# Altium schematic sheet Microcontroller.SchDoc(Microcontroller)
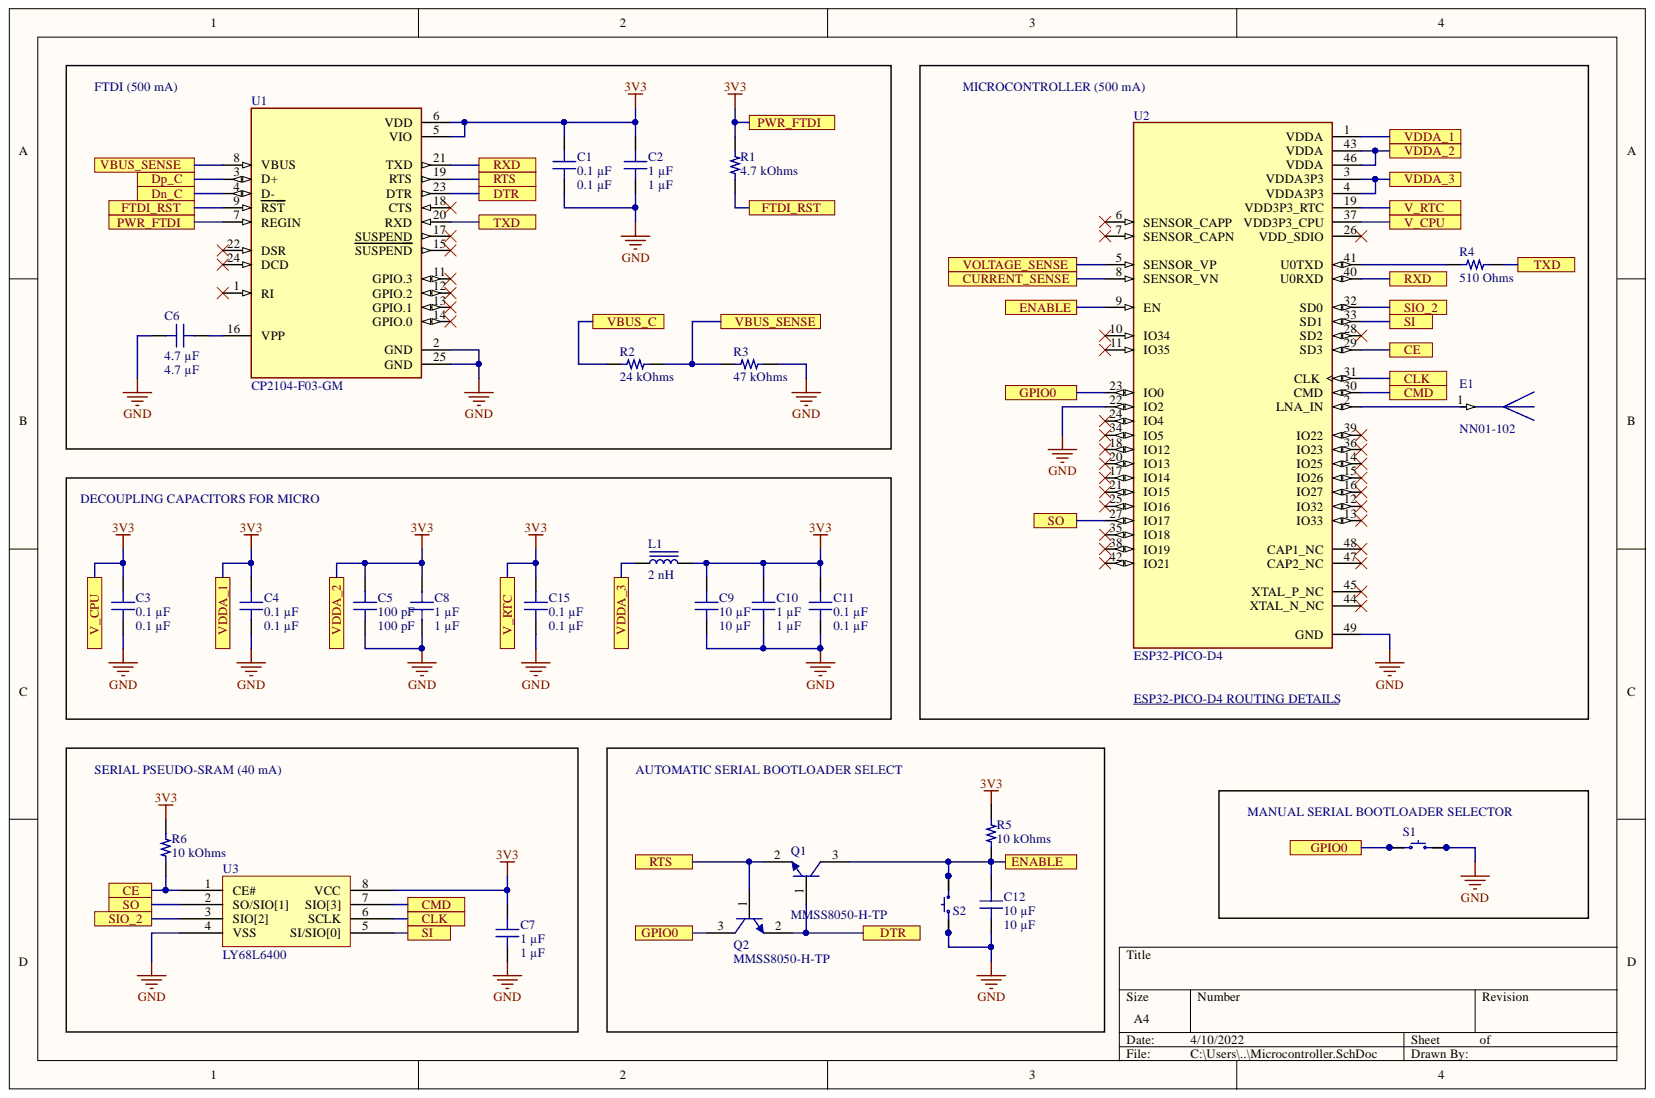
[diagram p0: Microcontroller.SchDoc]

## Parts
C1  0.1 µF  [CAP 0603_1608]
C2  1 µF  [CAP 0603_1608]
C3  0.1 µF  [CAP 0603_1608]
C4  0.1 µF  [CAP 0603_1608]
C5  100 pF  [CAP 0603_1608]
C6  4.7 µF  [CAP 0603_1608]
C7  1 µF  [CAP 0603_1608]
C8  1 µF  [CAP 0603_1608]
C9  10 µF  [CAP 0805_2012]
C10  1 µF  [CAP 0603_1608]
C11  0.1 µF  [CAP 0603_1608]
C12  10 µF  [CAP 0805_2012]
C15  0.1 µF  [CAP 0603_1608]
E1  NN01-102  [XCVR_NN01-102]
L1  2 nH  [IND 0402_1005]
Q1  MMSS8050-H-TP  [MCCSEMI SOT-23-3]
Q2  MMSS8050-H-TP  [MCCSEMI SOT-23-3]
R1  4.7 kOhms  [RES 0603_1608]
R2  24 kOhms  [RES 0603_1608]
R3  47 kOhms  [RES 0603_1608]
R4  510 Ohms  [RES 0603_1608]
R5  10 kOhms  [RES 0603_1608]
R6  10 kOhms  [RES 0603_1608]
S1  PTS645SM43SMTR92 LFS  [C&K PTS645]  (value not drawn)
S2  PTS645SM43SMTR92 LFS  [C&K PTS645]  (value not drawn)
U1  CP2104-F03-GM  [QFN50P400X400X80-25N]
U2  ESP32-PICO-D4  [PQFN50P700X700X104-49N]
U3  LY68L6400  [SOP-8_3.9x4.9x1.27P]

## Ports
CE, CLK, CMD, CURRENT_SENSE, Dn_C, Dp_C, DTR, ENABLE, FTDI_RST, GPIO0, PWR_FTDI, RTS, RXD, SI, SIO_2, SO, TXD, V_CPU, V_RTC, VBUS_C, VBUS_SENSE, VDDA_1, VDDA_2, VDDA_3, VOLTAGE_SENSE

## Nets
GND: C1.1 C2.2 C3.1 C4.2 C5.1 C6.2 C7.2 C8.1 C9.1 C10.1 C11.1 C12.1 C15.1 R3.1 S1.3 S1.4 S2.1 S2.2 U1.2(GND) U1.25(GND) U2.22(IO2) U2.49(GND) U3.4(VSS)
3V3: C1.2 C2.1 C3.2 C4.1 C5.2 C7.1 C8.2 C9.2 C10.2 C11.2 C15.2 L1.2 R1.2 R5.1 R6.1 U1.5(VIO) U1.6(VDD) U1.7(REGIN) U2.1(VDDA) U2.19(VDD3P3_RTC) U2.37(VDD3P3_CPU) U2.43(VDDA) U2.46(VDDA) U3.8(VCC) ; port PWR_FTDI, port VDDA_1, port VDDA_2, port V_CPU, port V_RTC
CE: R6.2 U2.29(SD3) U3.1(CE#) ; port CE
CLK: U2.31(CLK) U3.6(SCLK) ; port CLK
CMD: U2.30(CMD) U3.7(SIO[3]) ; port CMD
CURRENT_SENSE: U2.8(SENSOR_VN) ; port CURRENT_SENSE
Dn_C: U1.4(D-) ; port Dn_C
Dp_C: U1.3(D+) ; port Dp_C
DTR: Q1.1 Q2.2 U1.23(DTR) ; port DTR
ENABLE: C12.2 Q1.3 R5.2 S2.3 S2.4 U2.9(EN) ; port ENABLE
FTDI_RST: R1.1 U1.9(RST) ; port FTDI_RST
GPIO0: Q2.3 S1.1 S1.2 U2.23(IO0) ; port GPIO0
RTS: Q1.2 Q2.1 U1.19(RTS) ; port RTS
RXD: U1.21(TXD) U2.40(U0RXD) ; port RXD
SI: U2.33(SD1) U3.5(SI/SIO[0]) ; port SI
SIO_2: U2.32(SD0) U3.3(SIO[2]) ; port SIO_2
SO: U2.27(IO17) U3.2(SO/SIO[1]) ; port SO
TXD: R4.2 U1.20(RXD) ; port TXD
VBUS_C: R2.2 ; port VBUS_C
VBUS_SENSE: R2.1 R3.2 U1.8(VBUS) ; port VBUS_SENSE
VDDA_3: L1.1 U2.3(VDDA3P3) U2.4(VDDA3P3) ; port VDDA_3
VOLTAGE_SENSE: U2.5(SENSOR_VP) ; port VOLTAGE_SENSE
NetC6_1: C6.1 U1.16(VPP)
NetE1_1: E1.1 U2.2(LNA_IN)
NetR4_1: R4.1 U2.41(U0TXD)

Not connected: U1 1(RI) 11(GPIO.3) 12(GPIO.2) 13(GPIO.1) 14(GPIO.0) 15(SUSPEND) 17(SUSPEND) 18(CTS) 22(DSR) 24(DCD); U2 6(SENSOR_CAPP) 7(SENSOR_CAPN) 10(IO34) 11(IO35) 12(IO32) 13(IO33) 14(IO25) 15(IO26) 16(IO27) 17(IO14) 18(IO12) 20(IO13) 21(IO15) 24(IO4) 25(IO16) 26(VDD_SDIO) 28(SD2) 34(IO5) 35(IO18) 36(IO23) 38(IO19) 39(IO22) 42(IO21) 44(XTAL_N_NC) 45(XTAL_P_NC) 47(CAP2_NC) 48(CAP1_NC)

## Library data of the parts
C1 C3 C4 C11 C15 = C0603C104K5RAC7411: CAP CER 0.1UF 50V X7R 0603; manufacturer: KEMET; Tolerance: ±10%; Database Table Name: Capacitors - Ceramic - 0603; Voltage - Rated: 50V; Value: 0.1 µF; Thickness (Max): 0.035" (0.90mm); Temperature Coefficient: X7R; Supplier Part Number 1: 399-7374-2-ND; Supplier 1: DigiKey; Size / Dimension: 0.063" L x 0.031" W (1.60mm x 0.80mm); series: SMD Comm X7R; price: 0.01; Part Status: Active; Part Number: C0603C104K5RAC7411; partid: 2233; packaging: TapeAndReel; Package / Case: 0603 (1608 Metric); Operating Temperature: -55°C ~ 125°C; Mounting Type: Surface Mount, MLCC; Minimum Order: 15000; Lifecycle Status: Active; Library Name: Celestial Altium Library - altium_library.DbLib; lastupdated: 21/08/2022 8:00:52 PM; Device Package: 0603 (1608 Metric); componentlink2url: https://www.digikey.com/en/products/detail/kemet/C0603C104K5RAC7411/3317013; componentlink2description: DigiKey Link; componentlink1url: https://api.kemet.com/component-edge/download/datasheet/C0603C104K5RAC7411.pdf; componentlink1description: Datasheet; Component Kind: Standard; Capacitance: 0.1 µF; Applications: Bypass, Decoupling
C2 C7 C8 C10 = CC0603KRX7R6BB105: CAP CER 1UF 10V X7R 0603; manufacturer: Yageo; Tolerance: ±10%; Database Table Name: Capacitors - Ceramic - 0603; Voltage - Rated: 10V; Value: 1 µF; Thickness (Max): 0.035" (0.90mm); Temperature Coefficient: X7R; Supplier Part Number 1: 311-1796-1-ND; Supplier 1: DigiKey; Size / Dimension: 0.063" L x 0.031" W (1.60mm x 0.80mm); series: CC; price: 0.02; Part Status: Active; Part Number: CC0603KRX7R6BB105; partid: 2290; packaging: TapeAndReel; Package / Case: 0603 (1608 Metric); Operating Temperature: -55°C ~ 125°C; Mounting Type: Surface Mount, MLCC; Minimum Order: 1; Lifecycle Status: Active; Library Name: Celestial Altium Library - altium_library.DbLib; lastupdated: 21/08/2022 8:00:52 PM; Device Package: 0603 (1608 Metric); componentlink2url: https://www.digikey.com/en/products/detail/yageo/CC0603KRX7R6BB105/5195204; componentlink2description: DigiKey Link; componentlink1url: https://www.yageo.com/upload/media/product/productsearch/datasheet/mlcc/UPY-GPHC_X7R_6.3V-to-50V_18.pdf; componentlink1description: Datasheet; Component Kind: Standard; Capacitance: 1 µF; Applications: General Purpose
C5 = C0603C101K5GACAUTO: CAP CER 100PF 50V C0G/NP0 0603; manufacturer: KEMET; Tolerance: ±10%; Temperature Coefficient: C0G, NP0; Database Table Name: Capacitors - Ceramic - 0603; Voltage - Rated: 50V; Value: 100 pF; Thickness (Max): 0.034" (0.87mm); Supplier Part Number 1: 399-6843-1-ND; Supplier 1: DigiKey; Size / Dimension: 0.063" L x 0.031" W (1.60mm x 0.80mm); series: SMD Auto C0G; Ratings: AEC-Q200; price: 0.01; Part Status: Active; Part Number: C0603C101K5GACAUTO; partid: 2201; packaging: TapeAndReel; Package / Case: 0603 (1608 Metric); Operating Temperature: -55°C ~ 125°C; Mounting Type: Surface Mount, MLCC; Minimum Order: 1; Lifecycle Status: Active; Library Name: Celestial Altium Library - altium_library.DbLib; lastupdated: 25/08/2022 6:49:41 AM; Features: Low ESL; Device Package: 0603 (1608 Metric); componentlink2url: https://www.digikey.com/en/products/detail/kemet/C0603C101K5GACAUTO/3314842; componentlink2description: DigiKey Link; componentlink1url: https://api.kemet.com/component-edge/download/datasheet/C0603C101K5GACAUTO.pdf; componentlink1description: Datasheet; Component Kind: Standard; Capacitance: 100 pF; Applications: Automotive
C6 = GRM188Z71C475KE21J: CAP CER 4.7UF 16V X7R 0603; manufacturer: Murata Electronics; Tolerance: ±10%; Database Table Name: Capacitors - Ceramic - 0603; Voltage - Rated: 16V; Value: 4.7 µF; Thickness (Max): 0.039" (1.00mm); Temperature Coefficient: X7R; Supplier Part Number 1: 490-GRM188Z71C475KE21JCT-ND; Supplier 1: DigiKey; Size / Dimension: 0.063" L x 0.031" W (1.60mm x 0.80mm); series: GRM; price: 0.04; Part Status: Active; Part Number: GRM188Z71C475KE21J; partid: 41988; packaging: TapeAndReel; Package / Case: 0603 (1608 Metric); Operating Temperature: -55°C ~ 125°C; Mounting Type: Surface Mount, MLCC; Minimum Order: 1; Lifecycle Status: Active; Library Name: Celestial Altium Library - altium_library.DbLib; lastupdated: 21/08/2022 7:59:26 PM; Device Package: 0603 (1608 Metric); componentlink2url: https://www.digikey.com/en/products/detail/murata-electronics/GRM188Z71C475KE21J/16033436; componentlink2description: DigiKey Link; componentlink1url: https://search.murata.co.jp/Ceramy/image/img/A01X/G101/ENG/GRM188Z71C475KE21-01A.pdf; componentlink1description: Datasheet; Component Kind: Standard; Capacitance: 4.7 µF; Applications: General Purpose
C9 C12 = CGA4J1X7R0J106K125AC: CAP CER 10UF 6.3V X7R 0805; manufacturer: TDK Corporation; Tolerance: ±10%; Database Table Name: Capacitors - Ceramic - 0805; Voltage - Rated: 6.3V; Value: 10 µF; Thickness (Max): 0.057" (1.45mm); Temperature Coefficient: X7R; Supplier Part Number 1: 445-6971-1-ND; Supplier 1: DigiKey; Size / Dimension: 0.079" L x 0.049" W (2.00mm x 1.25mm); series: CGA; Ratings: AEC-Q200; price: 0.07; Part Status: Active; Part Number: CGA4J1X7R0J106K125AC; partid: 3016; packaging: TapeAndReel; Package / Case: 0805 (2012 Metric); Operating Temperature: -55°C ~ 125°C; Mounting Type: Surface Mount, MLCC; Minimum Order: 1; Lifecycle Status: Active; Library Name: Celestial Altium Library - altium_library.DbLib; lastupdated: 21/08/2022 8:04:19 PM; Device Package: 0805 (2012 Metric); componentlink2url: https://www.digikey.com/en/products/detail/tdk-corporation/CGA4J1X7R0J106K125AC/2672864; componentlink2description: DigiKey Link; componentlink1url: https://product.tdk.com/info/en/catalog/datasheets/mlcc_automotive_general_en.pdf?ref_disty=digikey; componentlink1description: Datasheet; Component Kind: Standard; Capacitance: 10 µF; Applications: Automotive
E1 = NN01-102: 2.45GHz Bluetooth, WLAN, Zigbee Chip RF Antenna 2.4GHz ~ 2.5GHz 1.7dBi Solder Surface Mount; MF: {'manufacturer': u'Ignion'}; Price: None; Package: None; MP: NN01-102; Library Name: NN01-102.SchLib; Component Kind: Standard; Availability: Unavailable
L1 = MHQ1005P2N0BT000: FIXED IND 2NH 1A 50 MOHM SMD; Manufacturer: TDK Corporation; Type: Multilayer; Tolerance: ±0.1nH; Shielding: Unshielded; Q @ Freq: 23 @ 250MHz; Material - Core: Ceramic, Non-Magnetic; Inductance Frequency - Test: 100 MHz; Frequency - Self Resonant: 8GHz; DC Resistance (DCR): 50mOhm Max; Database Table Name: Inductors - Power; Value: 2 nH; Supplier Part Number 1: 445-MHQ1005P2N0BT000CT-ND; Supplier Device Package: 0402 (1005 Metric); Supplier 1: DigiKey; Size / Dimension: 0.039" L x 0.024" W (1.00mm x 0.60mm); Series: MHQ-P; Price: 0.06; Part Status: Active; Part Number: MHQ1005P2N0BT000; PartId: 1330; Packaging: TapeAndReel; Package / Case: 0402 (1005 Metric); Operating Temperature: -55°C ~ 125°C; Mounting Type: Surface Mount; Minimum Order: 1; Lifecycle Status: Active; Library Name: Celestial Altium Library - altium_library.DbLib; LastUpdated: 1/09/2022 1:22:23 PM; Inductance: 2 nH; Height - Seated (Max): 0.024" (0.60mm); Device Package: 0402 (1005 Metric); Current Rating (Amps): 1 A; ComponentLink2URL: https://www.digikey.com/en/products/detail/tdk-corporation/MHQ1005P2N0BT000/4742522; ComponentLink2Description: DigiKey Link; ComponentLink1URL: https://product.tdk.com/info/en/catalog/datasheets/inductor_commercial_high-frequency_mhq1005p_en.pdf?ref_disty=digikey; ComponentLink1Description: Datasheet; Component Kind: Standard
Q1 Q2 = MMSS8050-H-TP: TRANS NPN 25V 1.5A SOT23; Manufacturer: Micro Commercial Co; Vce Saturation (Max) @ Ib, Ic: 500mV @ 80mA, 800mA; Power - Max: 625 mW; Frequency - Transition: 100MHz; DC Current Gain (hFE) (Min) @ Ic, Vce: 120 @ 100mA, 1V; Database Table Name: Transistors - Bipolar BJT - Single; Current - Collector Cutoff (Max): 100nA; Voltage - Collector Emitter Breakdown (Max): 25 V; Transistor Type: NPN; Supplier Part Number 1: MMSS8050-H-TPMSCT-ND; Supplier Device Package: SOT-23; Supplier 1: DigiKey; Series: -; Price: 0.03; Part Status: Active; Part Number: MMSS8050-H-TP; PartId: 279; Packaging: TapeAndReel; Package / Case: TO-236-3, SC-59, SOT-23-3; Operating Temperature: -55°C ~ 150°C (TJ); Mounting Type: Surface Mount; Minimum Order: 1; Lifecycle Status: Active; Library Name: Celestial Altium Library - altium_library.DbLib; LastUpdated: 21/08/2022 9:04:56 PM; Current - Collector (Ic) (Max): 1.5 A; ComponentLink2URL: https://www.digikey.com/en/products/detail/micro-commercial-co/MMSS8050-H-TP/2696476; ComponentLink2Description: DigiKey Link; ComponentLink1URL: https://www.mccsemi.com/pdf/Products/MMSS8050(SOT-23).pdf; ComponentLink1Description: Datasheet; Component Kind: Standard
R1 = RT0603FRE074K7L: RES SMD 4.7K OHM 1% 1/10W 0603; Manufacturer: Yageo; Temperature Coefficient: ±50ppm/°C; Power (Watts): 0.1W, 1/10W; Database Table Name: Resistors - Surface Mount - 0603; Value: 4.7 kOhms; Tolerance: ±1%; Supplier Part Number 1: YAG1238CT-ND; Supplier Device Package: 0603; Supplier 1: DigiKey; Size / Dimension: 0.063" L x 0.031" W (1.60mm x 0.80mm); Series: RT; Resistance: 4.7 kOhms; Price: 0.01; Part Status: Active; Part Number: RT0603FRE074K7L; PartId: 5678; Packaging: TapeAndReel; Package / Case: 0603 (1608 Metric); Operating Temperature: -55°C ~ 155°C; Number of Terminations: 2; Minimum Order: 1; Lifecycle Status: Active; Library Name: Celestial Altium Library - altium_library.DbLib; LastUpdated: 21/08/2022 8:23:08 PM; Height - Seated (Max): 0.022" (0.55mm); Device Package: 0603 (1608 Metric); Composition: Thin Film; ComponentLink2URL: https://www.digikey.com/en/products/detail/yageo/RT0603FRE074K7L/1075404; ComponentLink2Description: DigiKey Link; ComponentLink1URL: https://www.yageo.com/upload/media/product/productsearch/datasheet/rchip/PYu-RT_1-to-0.01_RoHS_L_11.pdf; ComponentLink1Description: Datasheet; Component Kind: Standard
R2 = RK73H1JTTD2402F: RES 24K OHM 1% 1/10W 0603; Manufacturer: KOA Speer Electronics, Inc.; Temperature Coefficient: ±100ppm/°C; Power (Watts): 0.1W, 1/10W; Database Table Name: Resistors - Surface Mount - 0603; Value: 24 kOhms; Tolerance: ±1%; Supplier Part Number 1: 2019-RK73H1JTTD2402FCT-ND; Supplier Device Package: 0603; Supplier 1: DigiKey; Size / Dimension: 0.063" L x 0.031" W (1.60mm x 0.80mm); Series: RK73H; Resistance: 24 kOhms; Ratings: AEC-Q200; Price: 0.01; Part Status: Active; Part Number: RK73H1JTTD2402F; PartId: 5156; Packaging: CutTape; Package / Case: 0603 (1608 Metric); Operating Temperature: -55°C ~ 155°C; Number of Terminations: 2; Minimum Order: 1; Lifecycle Status: Active; Library Name: Celestial Altium Library - altium_library.DbLib; LastUpdated: 21/08/2022 8:23:10 PM; Height - Seated (Max): 0.022" (0.55mm); Features: Automotive AEC-Q200, Moisture Resistant; Device Package: 0603 (1608 Metric); Composition: Thick Film; ComponentLink2URL: https://www.digikey.com/en/products/detail/koa-speer-electronics-inc/RK73H1JTTD2402F/9844964; ComponentLink2Description: DigiKey Link; ComponentLink1URL: http://www.koaspeer.com/catimages/Products/RK73H/RK73H.pdf; ComponentLink1Description: Datasheet; Component Kind: Standard
R3 = RT0603FRE0747KL: RES SMD 47K OHM 1% 1/10W 0603; Manufacturer: Yageo; Temperature Coefficient: ±50ppm/°C; Power (Watts): 0.1W, 1/10W; Database Table Name: Resistors - Surface Mount - 0603; Value: 47 kOhms; Tolerance: ±1%; Supplier Part Number 1: YAG3355CT-ND; Supplier Device Package: 0603; Supplier 1: DigiKey; Size / Dimension: 0.063" L x 0.031" W (1.60mm x 0.80mm); Series: RT; Resistance: 47 kOhms; Price: 0.01; Part Status: Active; Part Number: RT0603FRE0747KL; PartId: 5674; Packaging: TapeAndReel; Package / Case: 0603 (1608 Metric); Operating Temperature: -55°C ~ 155°C; Number of Terminations: 2; Minimum Order: 1; Lifecycle Status: Active; Library Name: Celestial Altium Library - altium_library.DbLib; LastUpdated: 21/08/2022 8:23:08 PM; Height - Seated (Max): 0.022" (0.55mm); Device Package: 0603 (1608 Metric); Composition: Thin Film; ComponentLink2URL: https://www.digikey.com/en/products/detail/yageo/RT0603FRE0747KL/1075386; ComponentLink2Description: DigiKey Link; ComponentLink1URL: https://www.yageo.com/upload/media/product/productsearch/datasheet/rchip/PYu-RT_1-to-0.01_RoHS_L_11.pdf; ComponentLink1Description: Datasheet; Component Kind: Standard
R4 = RMCF0603JT510R: RES 510 OHM 5% 1/10W 0603; Manufacturer: Stackpole Electronics Inc; Temperature Coefficient: ±200ppm/°C; Power (Watts): 0.1W, 1/10W; Database Table Name: Resistors - Surface Mount - 0603; Value: 510 Ohms; Tolerance: ±5%; Supplier Part Number 1: RMCF0603JT510RCT-ND; Supplier Device Package: 0603; Supplier 1: DigiKey; Size / Dimension: 0.061" L x 0.031" W (1.55mm x 0.80mm); Series: RMCF; Resistance: 510 Ohms; Ratings: AEC-Q200; Price: 0.01; Part Status: Active; Part Number: RMCF0603JT510R; PartId: 5929; Packaging: CutTape; Package / Case: 0603 (1608 Metric); Operating Temperature: -55°C ~ 155°C; Number of Terminations: 2; Minimum Order: 1; Lifecycle Status: Active; Library Name: Celestial Altium Library - altium_library.DbLib; LastUpdated: 25/08/2022 7:11:50 AM; Height - Seated (Max): 0.022" (0.55mm); Features: Automotive AEC-Q200; Device Package: 0603 (1608 Metric); Composition: Thick Film; ComponentLink2URL: https://www.digikey.com/en/products/detail/stackpole-electronics-inc/RMCF0603JT510R/1757941; ComponentLink2Description: DigiKey Link; ComponentLink1URL: https://www.seielect.com/catalog/sei-rmcf_rmcp.pdf; ComponentLink1Description: Datasheet; Component Kind: Standard
R5 R6 = RCS060310K0JNEA: RES SMD 10K OHM 5% 1/4W 0603; Manufacturer: Vishay Dale; Temperature Coefficient: ±200ppm/°C; Power (Watts): 0.25W, 1/4W; Database Table Name: Resistors - Surface Mount - 0603; Value: 10 kOhms; Tolerance: ±5%; Supplier Part Number 1: 541-2817-1-ND; Supplier Device Package: 0603; Supplier 1: DigiKey; Size / Dimension: 0.061" L x 0.033" W (1.55mm x 0.85mm); Series: RCS e3; Resistance: 10 kOhms; Ratings: AEC-Q200; Price: 0.01; Part Status: Active; Part Number: RCS060310K0JNEA; PartId: 5383; Packaging: TapeAndReel; Package / Case: 0603 (1608 Metric); Operating Temperature: -55°C ~ 155°C; Number of Terminations: 2; Minimum Order: 1; Lifecycle Status: Active; Library Name: Celestial Altium Library - altium_library.DbLib; LastUpdated: 25/08/2022 7:11:51 AM; Height - Seated (Max): 0.020" (0.50mm); Features: Automotive AEC-Q200, Pulse Withstanding; Device Package: 0603 (1608 Metric); Composition: Thick Film; ComponentLink2URL: https://www.digikey.com/en/products/detail/vishay-dale/RCS060310K0JNEA/5866963; ComponentLink2Description: DigiKey Link; ComponentLink1URL: http://www.vishay.com/docs/20065/rcse3.pdf; ComponentLink1Description: Datasheet; Component Kind: Standard
S1 S2 = PTS645SM43SMTR92 LFS: SWITCH TACTILE SPST-NO 0.05A 12V; Manufacturer: C&K; Switch Function: Off-Mom; Outline: 6.00mm x 6.00mm; Operating Force: 160gf; Illumination: Non-Illuminated; Database Table Name: Switches - Tactile; Contact Rating @ Voltage: 0.05A @ 12VDC; Actuator Type: Standard; Actuator Orientation: Top Actuated; Actuator Height off PCB, Vertical: 4.30mm; Termination Style: Gull Wing; Supplier Part Number 1: CKN9112CT-ND; Supplier 1: DigiKey; Series: PTS645; Price: 0.09; Part Status: Active; Part Number: PTS645SM43SMTR92 LFS; Packaging: TapeAndReel; Operating Temperature: -20°C ~ 60°C; Mounting Type: Surface Mount; Minimum Order: 1; Lifecycle Status: Active; Library Name: Celestial Altium Library - altium_library.DbLib; LastUpdated: 9/04/2020 9:59:00 AM; Features: Blue Actuator; ComponentLink2URL: http://digikey.com/product-detail/en/c-k/PTS645SM43SMTR92-LFS/CKN9112CT-ND/1146934; ComponentLink2Description: DigiKey Link; ComponentLink1URL: https://dznh3ojzb2azq.cloudfront.net/products/Tactile/PTS645/documents/datasheet.pdf; ComponentLink1Description: Datasheet; Component Kind: Standard; Circuit: SPST-NO
U1 = CP2104-F03-GM: USB-to-UART 1-CH 576byte FIFO 3.3V 24-Pin QFN EP T/R; MF: {'manufacturer': u'Silicon Labs'}; Price: None; Package: None; MP: CP2104-F03-GM; Library Name: CP2104-F03-GM.SchLib; Component Kind: Standard; Availability: Unavailable
U2 = ESP32-PICO-D4: Bluetooth, WiFi 802.11b/g/n, Bluetooth v4.2 +EDR, Class 1, 2 and 3 Transceiver Module 2.4GHz ~ 2.5GHz Surface Mount; MF: {'manufacturer': u'Espressif Systems'}; Price: None; Package: None; MP: ESP32-PICO-D4; Library Name: ESP32-PICO-D4.SchLib; Component Kind: Standard; Availability: Unavailable
U3 = LY68L6400SLIT: 64M Bits Serial Pseudo-SRAM with SPI and QPI; Component Kind: Standard; Library Name: Power_Measurement.SchLib
